# Altium schematic sheet Teensy Connections for T to E.SchDoc("Teensy Connections for T to E")
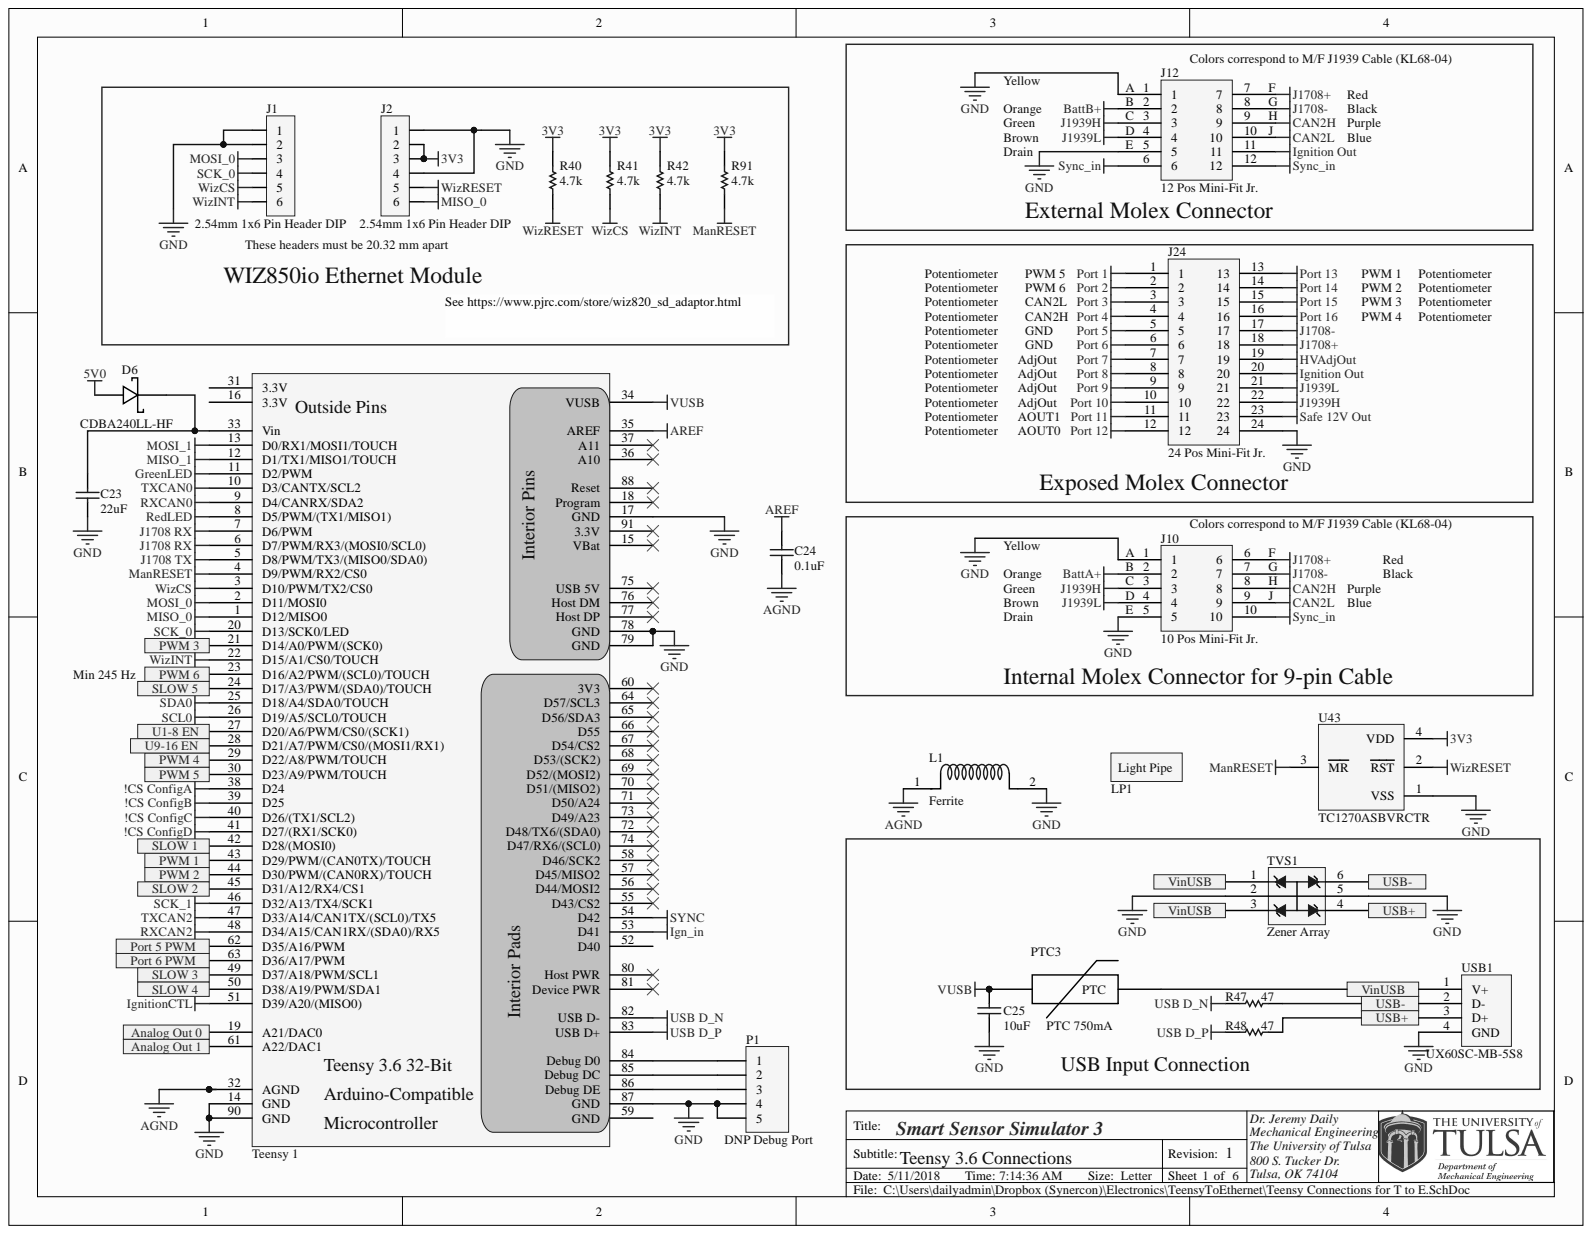
[diagram p0: Teensy Connections for T to E.SchDoc]

## Parts
C23  22uF  [1206]
C24  0.1uF  [0603]
C25  10uF  [0603]
D6  CDBA240LL-HF  [SMA with A and K]
J1  2.54mm 1x6 Pin Header DIP  [HDR1X6]
J2  2.54mm 1x6 Pin Header DIP  [HDR1X6]
J10  10 Pos Mini-Fit Jr.  [10 pos Mini-Fit Jr. R/A Header]
J12  12 Pos Mini-Fit Jr.  Manufacturer: Molex  [12 pos Mini-Fit Jr. R/A Header]
J24  24 Pos Mini-Fit Jr.  [24 pos Mini-Fit Jr. R/A Header]
L1  Ferrite  [0805]
LP1  DNP Light Pipe  [Lightpipe - Dual]  (value not drawn)
P1  DNP Debug Port  [HDR1X5]
PTC3  PTC 750mA  [1206]
R40  4.7k  [0603]
R41  4.7k  [0603]
R42  4.7k  [0603]
R47  47  [0603]
R48  47  [0603]
R91  4.7k  [0603]
Teensy_1  Teensy 3.6  [Teensy 3.6 Surface Mount]
TVS1  Zener Array  [SOT23-6]
U43  TC1270ASBVRCTR  [SOT-143-RC4_N]
USB1  UX60SC-MB-5S8  [USB Mini UX60S]

## Ports
Analog Out 0, Analog Out 1, Port 5 PWM, Port 6 PWM, PWM 1, PWM 2, PWM 3, PWM 4, PWM 5, PWM 6, SLOW 1, SLOW 2, SLOW 3, SLOW 4, SLOW 5, U1-8 EN, U9-16 EN, USB+, USB-, VinUSB

## Nets
3V3: J2.2 J2.3 R40.1 R41.1 R42.1 R91.1 U43.4(VDD)
5V0: D6.1
!CS ConfigA: Teensy_1.38(D24)
!CS ConfigB: Teensy_1.39(D25)
!CS ConfigC: Teensy_1.40(D26/(TX1/SCL2))
!CS ConfigD: Teensy_1.41(D27/(RX1/SCK0))
AGND: C24.1 L1.1 Teensy_1.32(AGND)
AREF: C24.2 Teensy_1.35(AREF)
BattA+: J10.2
BattB+: J12.2
CAN2H: J10.8 J12.9
CAN2L: J10.9 J12.10
GND: C23.1 C25.2 J1.1 J1.2 J2.1 J2.4 J10.1 J10.5 J12.1 J12.5 J24.24 L1.2 P1.4 P1.5 Teensy_1.14(GND) Teensy_1.17(GND) Teensy_1.78(GND) Teensy_1.79(GND) Teensy_1.87(GND) Teensy_1.90(GND) TVS1.2 TVS1.5 U43.1(VSS) USB1.4(GND)
GreenLED: Teensy_1.11(D2/PWM)
HVAdjOut: J24.19
Ign_in: Teensy_1.53(D41)
Ignition Out: J12.11 J24.20
IgnitionCTL: Teensy_1.51(D39/A20/(MISO0))
J1708 RX: Teensy_1.6(D7/PWM/RX3/(MOSI0/SCL0)) Teensy_1.7(D6/PWM)
J1708 TX: Teensy_1.5(D8/PWM/TX3/(MISO0/SDA0))
J1708+: J10.6 J12.7 J24.18
J1708-: J10.7 J12.8 J24.17
J1939H: J10.3 J12.3 J24.22
J1939L: J10.4 J12.4 J24.21
ManRESET: R91.2 Teensy_1.4(D9/PWM/RX2/CS0) U43.3(MR)
MISO_0: J2.6 Teensy_1.1(D12/MISO0)
MISO_1: Teensy_1.12(D1/TX1/MISO1/TOUCH)
MOSI_0: J1.3 Teensy_1.2(D11/MOSI0)
MOSI_1: Teensy_1.13(D0/RX1/MOSI1/TOUCH)
Port 1: J24.1
Port 2: J24.2
Port 3: J24.3
Port 4: J24.4
Port 5: J24.5
Port 6: J24.6
Port 7: J24.7
Port 8: J24.8
Port 9: J24.9
Port 10: J24.10
Port 11: J24.11
Port 12: J24.12
Port 13: J24.13
Port 14: J24.14
Port 15: J24.15
Port 16: J24.16
RedLED: Teensy_1.8(D5/PWM/(TX1/MISO1))
RXCAN0: Teensy_1.9(D4/CANRX/SDA2)
RXCAN2: Teensy_1.48(D34/A15/CAN1RX/(SDA0)/RX5)
Safe 12V Out: J24.23
SCK_0: J1.4 Teensy_1.20(D13/SCK0/LED)
SCK_1: Teensy_1.46(D32/A13/TX4/SCK1)
SCL0: Teensy_1.26(D19/A5/SCL0/TOUCH)
SDA0: Teensy_1.25(D18/A4/SDA0/TOUCH)
SYNC: Teensy_1.54(D42)
Sync_in: J10.10 J12.6 J12.12
TXCAN0: Teensy_1.10(D3/CANTX/SCL2)
TXCAN2: Teensy_1.47(D33/A14/CAN1TX/(SCL0)/TX5)
USB D_N: R47.1 Teensy_1.82(USB_D-)
USB D_P: R48.1 Teensy_1.83(USB_D+)
VUSB: C25.1 PTC3.1 Teensy_1.34(VUSB)
WizCS: J1.5 R41.2 Teensy_1.3(D10/PWM/TX2/CS0)
WizINT: J1.6 R42.2 Teensy_1.22(D15/A1/CS0/TOUCH)
WizRESET: J2.5 R40.2 U43.2(RST)
Analog Out 0: Teensy_1.19(A21/DAC0) ; port Analog Out 0
Analog Out 1: Teensy_1.61(A22/DAC1) ; port Analog Out 1
Port 5 PWM: Teensy_1.62(D35/A16/PWM) ; port Port 5 PWM
Port 6 PWM: Teensy_1.63(D36/A17/PWM) ; port Port 6 PWM
PWM 1: Teensy_1.43(D29/PWM/(CAN0TX)/TOUCH) ; port PWM 1
PWM 2: Teensy_1.44(D30/PWM/(CAN0RX)/TOUCH) ; port PWM 2
PWM 3: Teensy_1.21(D14/A0/PWM/(SCK0)) ; port PWM 3
PWM 4: Teensy_1.29(D22/A8/PWM/TOUCH) ; port PWM 4
PWM 5: Teensy_1.30(D23/A9/PWM/TOUCH) ; port PWM 5
PWM 6: Teensy_1.23(D16/A2/PWM/(SCL0)/TOUCH) ; port PWM 6
SLOW 1: Teensy_1.42(D28/(MOSI0)) ; port SLOW 1
SLOW 2: Teensy_1.45(D31/A12/RX4/CS1) ; port SLOW 2
SLOW 3: Teensy_1.49(D37/A18/PWM/SCL1) ; port SLOW 3
SLOW 4: Teensy_1.50(D38/A19/PWM/SDA1) ; port SLOW 4
SLOW 5: Teensy_1.24(D17/A3/PWM/(SDA0)/TOUCH) ; port SLOW 5
U1-8 EN: Teensy_1.27(D20/A6/PWM/CS0/(SCK1)) ; port U1-8 EN
U9-16 EN: Teensy_1.28(D21/A7/PWM/CS0/(MOSI1/RX1)) ; port U9-16 EN
USB+: R48.2 TVS1.4 USB1.3(D+) ; port USB+
USB-: R47.2 TVS1.6 USB1.2(D-) ; port USB-
VinUSB: PTC3.2 TVS1.1 TVS1.3 USB1.1(V+) ; port VinUSB
NetC23_2: C23.2 D6.2 Teensy_1.33(Vin)
NetP1_1: P1.1 Teensy_1.84(Debug_D0)
NetP1_2: P1.2 Teensy_1.85(Debug_DC)
NetP1_3: P1.3 Teensy_1.86(Debug_DE)

Not connected: Teensy_1 15(VBat) 16(3.3V) 18(Program) 31(3.3V) 36(A10) 37(A11) 52(D40) 55(D43/CS2) 56(D44/MOSI2) 57(D45/MISO2) 58(D46/SCK2) 59(GND) 60(3V3) 64(D57/SCL3) 65(D56/SDA3) 66(D55) 67(D54/CS2) 68(D53/(SCK2)) 69(D52/(MOSI2)) 70(D51/(MISO2)) 71(D50/A24) 72(D48/TX6/(SDA0)) 73(D49/A23) 74(D47/RX6/(SCL0)) 75(USB_5V) 76(Host_DM) 77(Host_DP) 80(Host_PWR) 81(Device_PWR) 88(Reset) 91(3.3V)

## Library data of the parts
C23 = Cap: 22µF ±20% 25V Ceramic Capacitor X5R 1206 (3216 Metric); PartNumber: 12063D226MAT2A; Manufacturer: AVX Corporation; Alternative: Acceptable; Supplier Part Number 1: 478-10040-1-ND; Supplier 1: Digi-Key; Simulation: CAP; Signal Integrity: Cap; Library Name: SSS Integrated Library.IntLib; Component Kind: Standard
C24 = Cap: 0.1µF -20%, +80% 25V Ceramic Capacitor Y5V (F) 0603 (1608 Metric); PartNumber: 06033G104ZAT2A; Manufacturer: AVX Corporation; Alternative: Acceptable; Supplier Part Number 1: 478-1259-1-ND; Supplier 1: Digi-Key; Simulation: CAP; Signal Integrity: Cap; Library Name: SSS Integrated Library.IntLib; Component Kind: Standard
C25 = Cap: 10µF ±20% 25V Ceramic Capacitor X5R 0603 (1608 Metric); PartNumber: ZRB18AR61E106ME01L; Manufacturer: Murata Electronics North America; Alternative: Acceptable; Supplier Part Number 1: 490-10991-1-ND; Supplier 1: Digi-Key; Simulation: CAP; Signal Integrity: Cap; Library Name: SSS Integrated Library.IntLib; Component Kind: Standard
D6 = Schottky Diode: Diode Schottky 40V 2A Surface Mount DO-214AC (SMA); Manufacturer: Comchip Technology; Alternative: Acceptable; Supplier Part Number 1: 641-1698-1-ND; Supplier 1: Digi-Key; PartNumber: CDBA240LL-HF; Library Name: SSS Integrated Library.IntLib; Component Kind: Standard
J1 J2 = 2.54mm 1x6 Pin Header DIP: PartNumber: WIZ850io; Manufacturer: WIZnet; Alternative: None; Supplier Part Number 1: 950-WIZ850IO; Supplier 1: Mouser; Description: 2.54mm 1x6 Pin Header DIP; Component Kind: Standard; Library Name: Scott_lib.IntLib
J10 = 10 Pos Header: 10 Positions Header - Shrouded Connector 0.165in (4.20mm) Through Hole - Right Angle Gold; Manufacturer: Molex; Alternative: None; Supplier Part Number 1: WM21367-ND; Supplier 1: Digi-Key; PartNumber: 39-30-1102; Library Name: Molex Mini-Fit Jr.IntLib; Component Kind: Standard
J12 = 12 Pos Header: 12 Position Header; Alternative: None; Supplier Part Number 1: WM1356-ND; Supplier 1: Digi-Key; PartNumber: 39-30-1120; Library Name: Molex Mini-Fit Jr.IntLib; Component Kind: Standard
J24 = 24 Pos Header: 24 Positions Header  Shrouded Connector 0.165in (4.20mm) Through Hole  Right Angle Gold; Manufacturer: Molex; Alternative: None; Supplier Part Number 1: WM21374-ND; Supplier 1: Digi-Key; PartNumber: 39-30-1242; Library Name: Molex Mini-Fit Jr.IntLib; Component Kind: Standard
L1 = Ferrite Bead: Ferrite Beads MultLyr Chip Bead 600ohm 0805 2000mA; PartNumber: 2508056017Y2; Manufacturer: Fair-Rite; Alternative: Acceptable; Supplier Part Number 1: 623-2508056017Y2; Supplier 1: Mouser; Library Name: SSS Integrated Library.IntLib; Component Kind: Standard
LP1 = Light Pipe: Light Pipe 2 High Clear Rigid Round with Domed Top, 3mm Board Mount, Press Fit, Right Angle; PartNumber: LP-230; Manufacturer: Bivar Inc.; Alternative: None; Supplier Part Number 1: 492-1859-ND; Supplier 1: Digi-Key; Library Name: SSS Integrated Library.IntLib; Component Kind: Standard
P1 = Header 5: Header, 5-Pin; Alternative: None; Signal Integrity: Connector; Library Name: Miscellaneous Connectors.IntLib; Component Kind: Standard
PTC3 = PTC: PTC RESTTBLE 0.75A 16V CHIP 1206; PartNumber: 0ZCJ0075AF2E; Manufacturer: Bel Fuse Inc; Alternative: None; Supplier Part Number 1: 507-1805-1-ND; Supplier 1: Digi-Key; Library Name: SSS Integrated Library.IntLib; Component Kind: Standard
R40-R42 R91 = Chip Resistor: 4.7 kOhms ±1% 0.1W, 1/10W Chip Resistor 0603 (1608 Metric) Automotive AEC-Q200 Thick Film; PartNumber: ERJ-3EKF4701V; Manufacturer: Panasonic Electronic Components; Alternative: Acceptable; Supplier Part Number 1: P4.70KHCT-ND; Supplier 1: Digi-Key; Library Name: SSS Integrated Library.IntLib; Component Kind: Standard
R47 R48 = Chip Resistor: 47 Ohms ±1% 0.1W, 1/10W Chip Resistor 0603 (1608 Metric) Automotive AEC-Q200 Thick Film; PartNumber: ERJ-3EKF47R0V; Manufacturer: Panasonic Electronic Components; Alternative: Acceptable; Supplier Part Number 1: P47.0HCT-ND; Supplier 1: Digi-Key; Library Name: SSS Integrated Library.IntLib; Component Kind: Standard
Teensy_1 = Teensy 3.6: K66 Teensy 3.6 Kinetis MCU 32-Bit ARM® Cortex®-M4 Embedded Evaluation Board; PartNumber: DEV-14057; Manufacturer: SparkFun Electronics; Alternative: None; Supplier Part Number 1: 1568-1442-ND; Supplier 1: Digi-Key; Library Name: Teensy.IntLib; Component Kind: Standard
TVS1 = TVS Diode Array: TVS DIODE 5VWM 6.8VC SOT23; PartNumber: 82402304; Manufacturer: Wurth Electronics Inc; Alternative: None; Supplier Part Number 1: 732-4476-1-ND; Supplier 1: Digi-Key; Library Name: Protection Devices.IntLib; Component Kind: Standard
U43 = TC1270ASBVRCTR: Voltage Supervisor with Manual Reset Input, 4-Pin SOT-143, VTRIP:2.85V (min.) / 2.93V (typ.) / 3.00V (max.), Tape and Reel; PartNumber: TC1270ASVRCTR; Manufacturer: Microchip; Alternative: None; Supplier Part Number 1: TC1270ASVRCCT-ND; Supplier 1: Digi-Key; Library Name: Microchip Power Management CPU-System Supervisor.IntLib; Component Kind: Standard
USB1 = USB-Rugged: USB - mini B USB 2.0 Receptacle Connector 5 Position Surface Mount, Right Angle; Through Hole; Manufacturer: Hirose; Alternative: None; Supplier Part Number 1: H11589CT-ND; Supplier 1: Digi-Key; PartNumber: UX60SC-MB-5S8; Library Name: SSS Integrated Library.IntLib; Component Kind: Standard
